# Altium schematic sheet Memory.SchDoc(Memory)
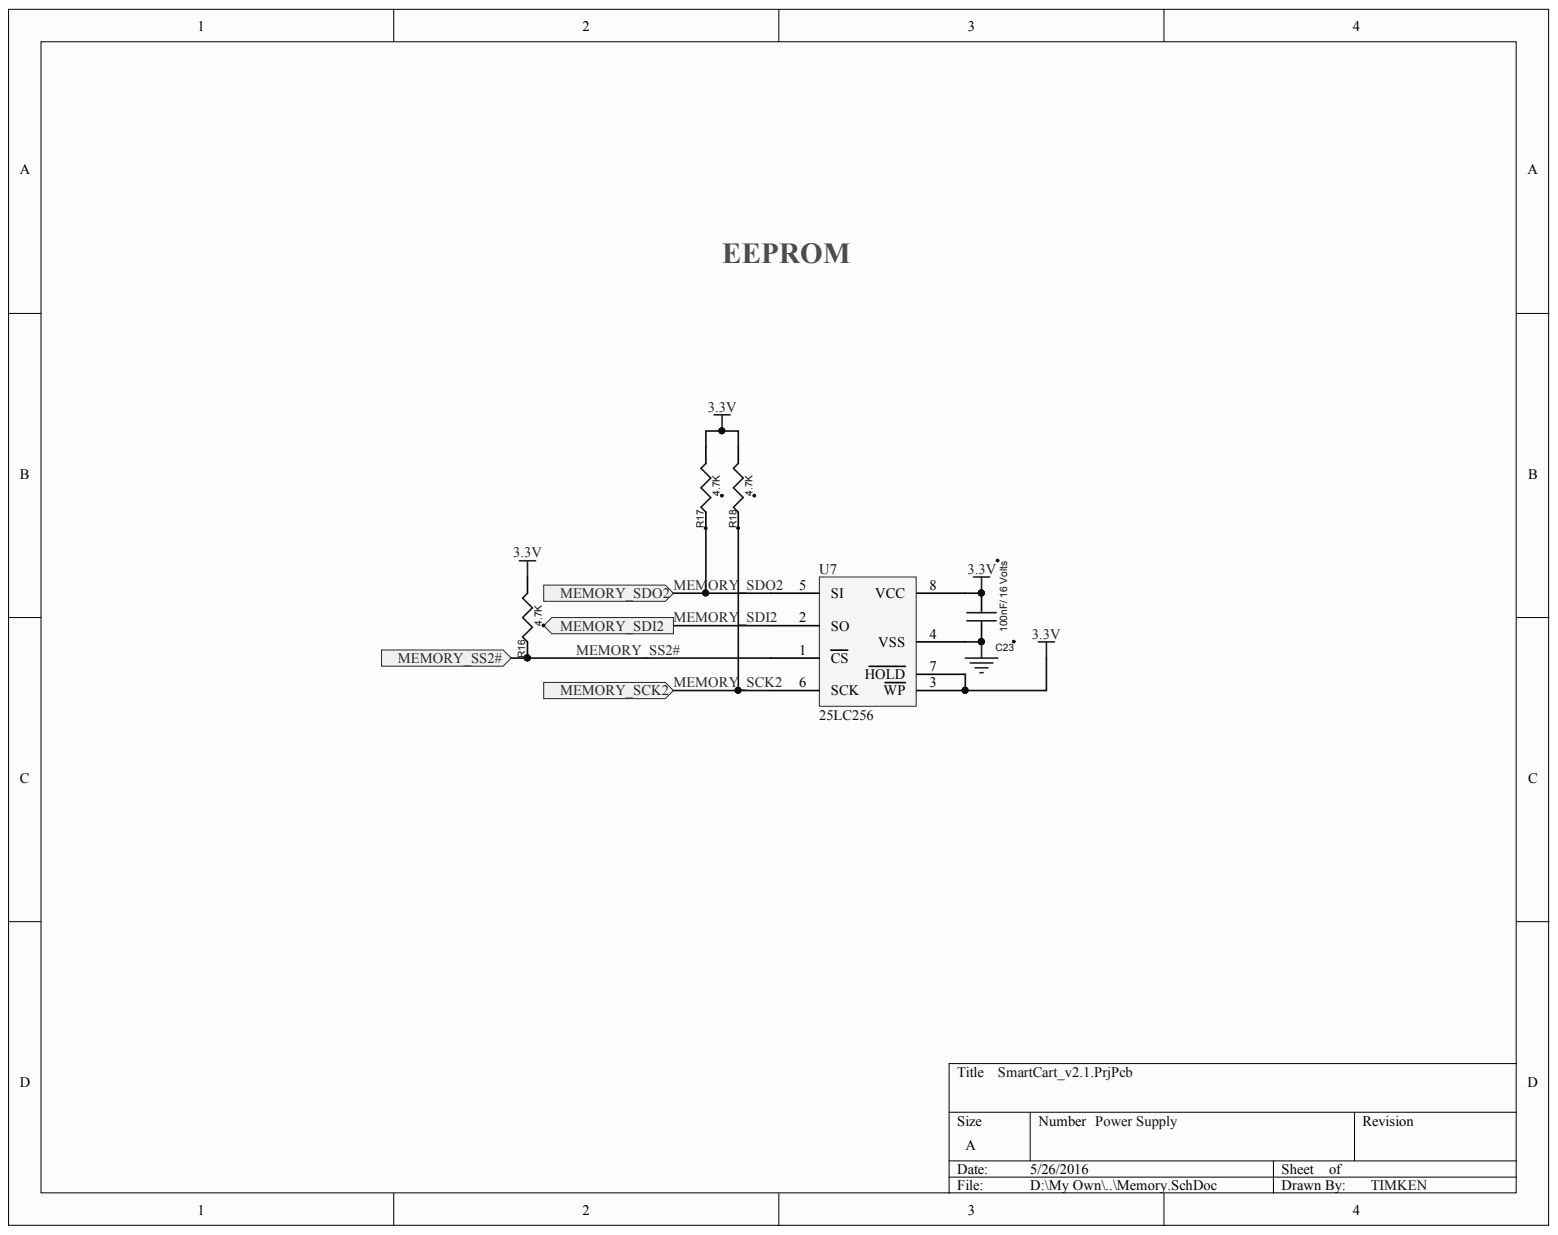
[diagram p0: Memory.SchDoc]

## Parts
C23  100nF/ 16 Volts  [C0805]
R16  4.7K  [0.079" L x 0.049" W (2.00mm x 1.25mm)  (0805 (2012 Metric)  )]
R17  4.7K  [0.079" L x 0.049" W (2.00mm x 1.25mm)  (0805 (2012 Metric)  )]
R18  4.7K  [0.079" L x 0.049" W (2.00mm x 1.25mm)  (0805 (2012 Metric)  )]
U7  25LC256  [8-SOIC (0.154", 3.90mm Width)]

## Ports
MEMORY_SCK2, MEMORY_SDI2, MEMORY_SDO2, MEMORY_SS2#

## Nets
3.3V: C23.1 R16.2 R17.2 R18.2 U7.3(WP) U7.7(HOLD) U7.8(VCC)
GND_POWER: C23.2 U7.4(VSS)
MEMORY_SCK2: R18.1 U7.6(SCK) ; port MEMORY_SCK2
MEMORY_SDI2: U7.2(SO) ; port MEMORY_SDI2
MEMORY_SDO2: R17.1 U7.5(SI) ; port MEMORY_SDO2
MEMORY_SS2#: R16.1 U7.1(CS) ; port MEMORY_SS2#

## Library data of the parts
C23 = CAP NP: Descr: CAPACITOR, 0805, 100NF, 16V, X7R; M P No: CC0805KRX7R7BB104; Vendor: Farnell; V P No: 644160RL; Component Kind: Standard; Library Name: combined_cart_schematic_lib.SchLib
R16-R18 = RESISTOR: Descr: RES 10K OHM 1/2W 5% 0805 SMD; M P No: ERJ-P06J103V; Vendor: Digikey; V P No: P10KADTR-ND; Component Kind: Standard; Library Name: combined_cart_schematic_lib.SchLib
U7 = 25LC256: Descr: IC EEPROM 256KBIT 10MHZ 8SOIC; M P No: 25LC256-I/SN; Vendor: Digikey; V P No: 25LC256-I/SN-ND; Component Kind: Standard; Price: 1.39000; Library Name: combined_cart_schematic_lib.SchLib
